# Altium schematic sheet 03-LCD.SchDoc
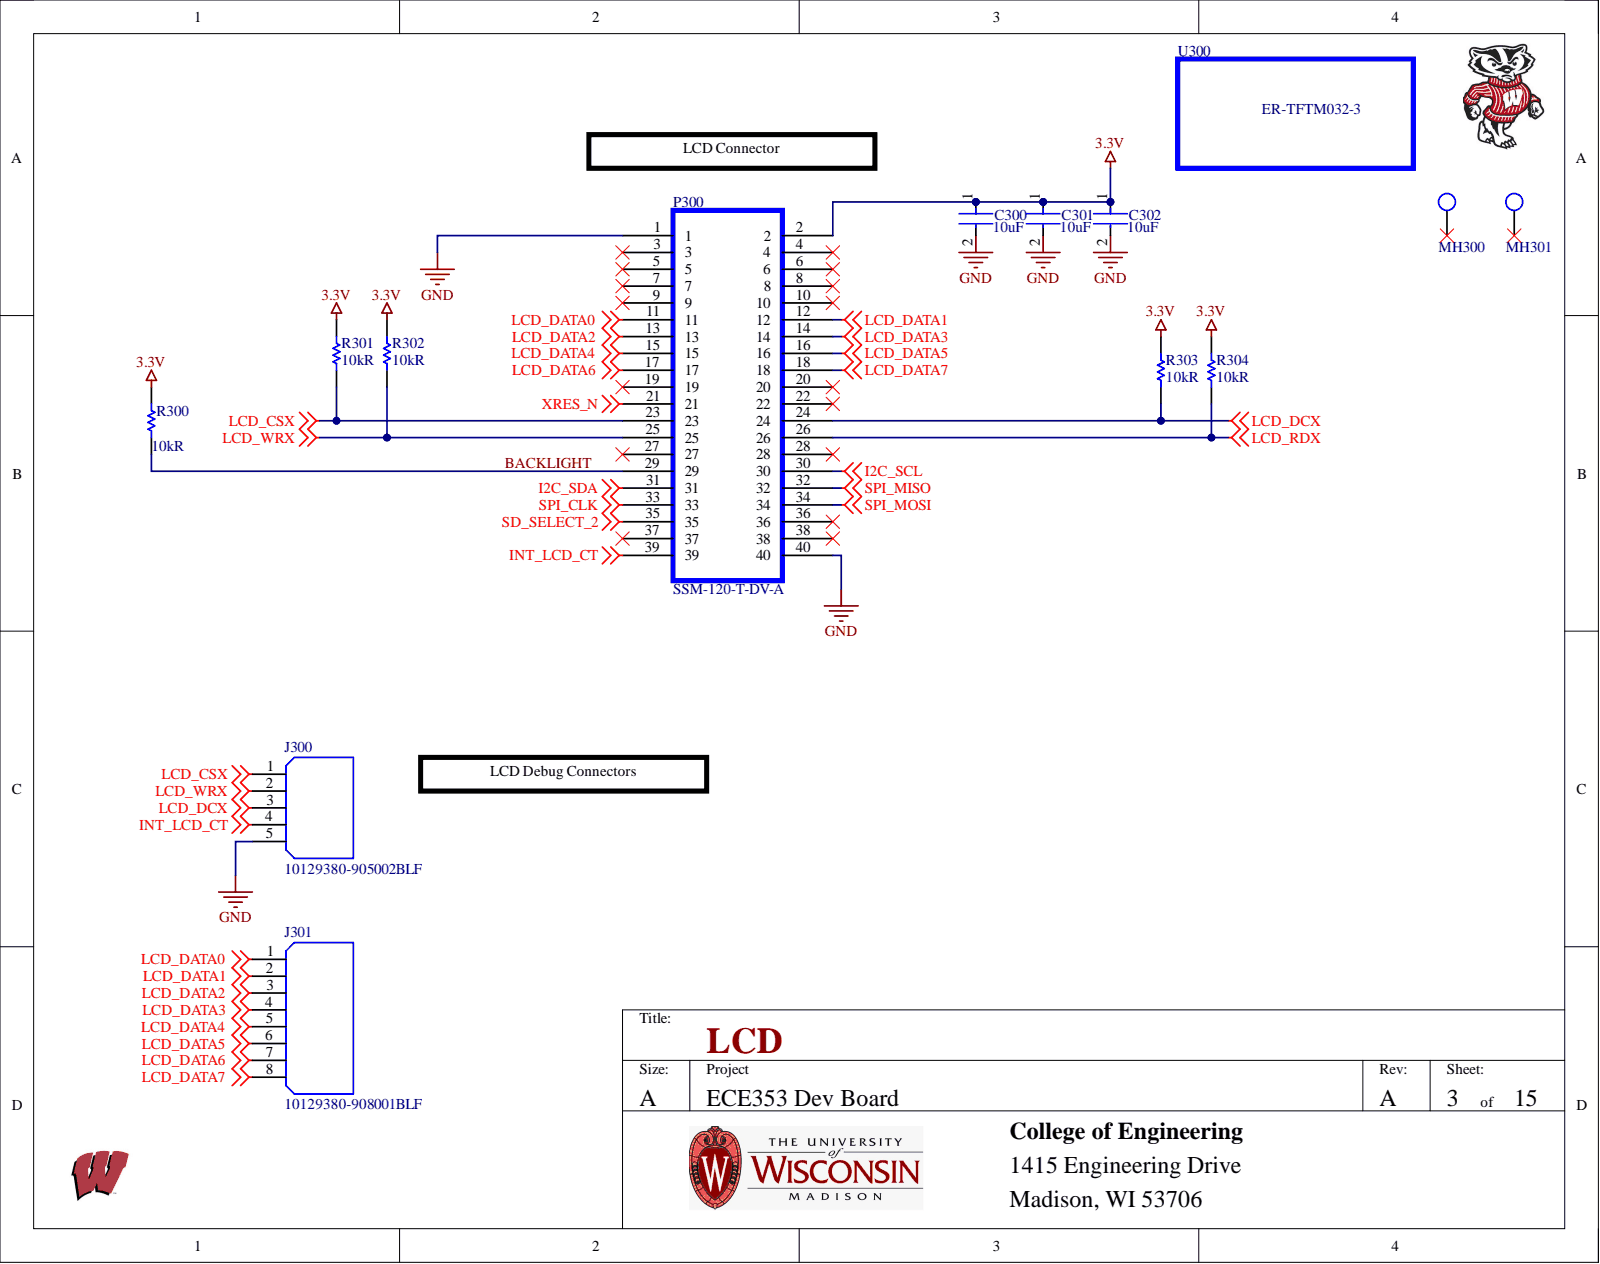
[diagram p0: 03-LCD.SchDoc]

## Parts
C300  value 10uF  Capacitance: 10uF  [FP-C0805C-DG-MFG]  (hidden comment: C0805C106K8PACTU)
C301  value 10uF  Capacitance: 10uF  [FP-C0805C-DG-MFG]  (hidden comment: C0805C106K8PACTU)
C302  value 10uF  Capacitance: 10uF  [FP-C0805C-DG-MFG]  (hidden comment: C0805C106K8PACTU)
J300  10129380-905002BLF  [AMPHENOL_10129380-905002BLF]
J301  10129380-908001BLF  [AMPHENOL_10129380-908001BLF]
MH300  MHOLE_3.5mm  [MTHOLE_145_300KeepOut]  (value not drawn)  (no BOM)
MH301  MHOLE_3.5mm  [MTHOLE_145_300KeepOut]  (value not drawn)  (no BOM)
P300  SSM-120-T-DV-A  [SSM-120-T-DV-A]
R300  Resistance: 10kR  [RESC2013X60X35NL10T20]  (hidden comment: RC0805FR-0710KL)
R301  Resistance: 10kR  [RESC2013X60X35NL10T20]  (hidden comment: RC0805FR-0710KL)
R302  Resistance: 10kR  [RESC2013X60X35NL10T20]  (hidden comment: RC0805FR-0710KL)
R303  Resistance: 10kR  [RESC2013X60X35NL10T20]  (hidden comment: RC0805FR-0710KL)
R304  Resistance: 10kR  [RESC2013X60X35NL10T20]  (hidden comment: RC0805FR-0710KL)
U300  Manufacturer Part Number: ER-TFTM032-3  [ER-TFTM032-3_Mechanical]  (hidden comment: ER-TFTM032-3-Mechanicals)  (no BOM)

## Nets
3.3V: C300.1 C301.1 C302.1 P300.2 R300.2 R301.1 R302.1 R303.1 R304.1
GND: C300.2 C301.2 C302.2 J300.5 P300.1 P300.40
I2C_SCL: P300.30
I2C_SDA: P300.31
INT_LCD_CT: J300.4 P300.39
LCD_CSX: J300.1 P300.23 R301.2
LCD_DATA0: J301.1 P300.11
LCD_DATA1: J301.2 P300.12
LCD_DATA2: J301.3 P300.13
LCD_DATA3: J301.4 P300.14
LCD_DATA4: J301.5 P300.15
LCD_DATA5: J301.6 P300.16
LCD_DATA6: J301.7 P300.17
LCD_DATA7: J301.8 P300.18
LCD_DCX: J300.3 P300.24 R303.2
LCD_RDX: P300.26 R304.2
LCD_WRX: J300.2 P300.25 R302.2
SD_SELECT_2: P300.35
SPI_CLK: P300.33
SPI_MISO: P300.32
SPI_MOSI: P300.34
XRES_N: P300.21
BACKLIGHT: P300.29 R300.1

Not connected: MH300 1; MH301 1; P300 3 4 5 6 7 8 9 10 19 20 22 27 28 36 37 38

## Library data of the parts
C300-C302 = CMP-2007-03243-2: CAP CER 10UF 10V X5R 0805; 3DS Part Number: 220-00001; Manufacturer: Kemet; Dielectric Material: Ceramic; Dissipation Factor: 10%; Temperature Coefficient: 15%; Automotive: No; Case Code (Imperial): 0805; Case Code (Metric): 2012; Case/Package: 0805; Category: Cap; Component Kind: Standard; ComponentLink2Description: Datasheet; ComponentLink2URL: https://content.kemet.com/datasheets/KEM_C1006_X5R_SMD.pdf; Construction: Flat; Contact Plating: Tin,Matte; Depth: 1.25mm; Device Class L1: Passive Components; Device Class L2: Capacitors; Device Class L3: Ceramic Capacitors; Dielectric: X5R; Digikey Description: CAP CER 10UF 10V X5R 0805; Height: 1.4mm; Ipc Land Pattern Name: CAPC200125X125; Lead Free: Yes; Length: 2mm; Library Name: Altium Content Vault; Manufacturer 1: KEMET; Manufacturer Part Number: C0805C106K8PACTU; Manufacturer Part Number 1: C0805C106K8PACTU; Material: Ceramic; Max Operating Temperature: 85°C; Min Operating Temperature: -55°C; Mount: Surface Mount; Mouser Description: CAP CER 10UF 10V X5R 0805; Number of Pins: 2; Octopart: https://octopart.com/c0805c106k8pactu-kemet-98697; Package: C0805C-DG; Package Quantity: 1; Packaging: Tape and Reel; Pricing 1: 1=0.14000, 10=0.10300, 50=0.05840, 100=0.04830, 500=0.03388, 1000=0.02805 (USD); Radiation Hardening: No; Reach SVHC Compliant: Yes; RoHS: TRUE; RoHS Compliant: Yes; Series: C; Stock 1: 8391826; Supplier 1: Digi-Key; Supplier Part Number 1: 399-C0805C106K8PAC7800CT-ND; Temperature: -55°C to +85°C; Temperature Characteristic: X5R; Temperature Range High: +85°C; Temperature Range Low: -55°C; Termination: SMD/SMT; Thickness: 0.055inch; Tolerance: 10%; Voltage: 10V; Voltage Rating: 10V; Voltage Rating (DC): 10V; Width: 0.049inch
J300 = 10129380-905002BLF: Conn Unshrouded Header HDR 5 POS 2.54mm Solder ST SMD Poly Bag; Manufacturer 1: ICC; MF: AMPHENOL ICC / FCI; Availability: In Stock; Check_prices: https://www.snapeda.com/parts/10129380-905002BLF/Amphenol/view-part/?ref=eda; Component Kind: Standard; Library Name: ece353-2022.SchLib; Manufacturer Part Number 1: 10129380-905002BLF; MP: 10129380-905002BLF; Package: None; Price: None; Purchase-URL: https://pricing.snapeda.com/search/part/10129380-905002BLF/?ref=eda; RoHS: No; SnapEDA_Link: https://www.snapeda.com/parts/10129380-905002BLF/Amphenol/view-part/?ref=snap; Supplier 1: Digi-Key; Supplier Part Number 1: 10129380-905002BLF-ND
J301 = 10129380-908001BLF: Conn Unshrouded Header HDR 8 POS 2.54mm Solder ST SMD Poly Bag; Manufacturer 1: ICC; MF: Amphenol ICC (FCI); Availability: In Stock; Check_prices: https://www.snapeda.com/parts/10129380-908001BLF/Amphenol+FCI/view-part/?ref=eda; Component Kind: Standard; Library Name: ece353-2022.SchLib; Manufacturer Part Number 1: 10129380-908001BLF; MP: 10129380-908001BLF; Package: None; Price: None; Purchase-URL: https://pricing.snapeda.com/search/part/10129380-908001BLF/?ref=eda; RoHS: No; SnapEDA_Link: https://www.snapeda.com/parts/10129380-908001BLF/Amphenol+FCI/view-part/?ref=snap; Supplier 1: Digi-Key; Supplier Part Number 1: 10129380-908001BLF-ND
MH300 MH301 = MHOLE_3.5mm: Mounting Holde; Manufacturer Part Number: UW MTHOLE; Library Name: ece353-2016.SchLib
P300 = SSM-120-T-DV-A: Headers & Wire Housings Surface Mount PCB Socket Strips, 0.100 pitch; Manufacturer: Samtec; Connector Type: Receptacle; Gender: Female; Number of Contacts: 40; Pitch: 2.54mm; Voltage Rating (AC): 405V; Voltage Rating (DC): 572V; Category: Connectors; Component Kind: Standard; ComponentLink1Description: https://datasheet.ciiva.com/745/npxcxx2kfmx-rc10488-d-745417.pdf?src-supplier=Digi-Key; ComponentLink1URL: https://datasheet.ciiva.com/745/npxcxx2kfmx-rc10488-d-745417.pdf?src-supplier=Digi-Key; ComponentLink2Description: http://cloud.samtec.com/catalog_english/SSM_SM.PDF?src-supplier=Avnet; ComponentLink2URL: http://cloud.samtec.com/catalog_english/SSM_SM.PDF?src-supplier=Avnet; Contact Finish - Mating: TIN; Contact Material: Bronze; Contact Plating: Tin; Contact Shape: Square; Contact Type: Female Socket; Fastening Type: Pull; Insulation Color: Black; Insulation Material: Polymer; Library Name: ece353-2016.SchLib; Manufacturer Part Number: SSM-120-T-DV-A; Max Operating Temperature: 125°C; Min Operating Temperature: -55°C; Mounting Technology: Surface Mount; Number of Positions: 40; Number of Rows: 2; Pricing: 1=6.6 (USD); RoHS: Yes; RoHS Compliant: Yes; Series: SSM; Supplier: Digi-Key; Supplier Part Number: SSM-120-T-DV-A-ND; Termination: Solder
R300-R304 = CMP-1659-00013-4: Chip Resistor, 10 KOhm, +/- 1%, 0.125 W, -55 to 155 degC, 0805 (2012 Metric), RoHS, Tape and Reel; Manufacturer: Yageo; Max Power Dissipation: 125mW; Temperature Coefficient: 100ppm/°C; Voltage Rating: 150 V; Case Code (Imperial): 0805; Case Code (Metric): 2012; Case/Package: 0805; Category: Chip SMD Resistors; Component Kind: Standard; ComponentLink1Description: Manufacturer URL; ComponentLink1URL: http://www.yageo.com/; ComponentLink2Description: Datasheet; ComponentLink2URL: http://www.yageo.com/documents/recent/PYu-RC_Group_51_RoHS_L_04.pdf; Construction: Rectangular; DatasheetVersion: Rev. 4, 02/2016; Depth: 1.25mm; Features: Moisture Resistant; Height: 0.5 mm; Lead Free: Lead Free; Length: 2 mm; Library Name: Altium Content Vault; Manufacturer 1: Yageo; Manufacturer Part Number 1: RC0805FR-0710KL; Max Operating Temperature: 155 degC; Military Standard: Not; Min Operating Temperature: -55 degC; Mount: Surface Mount; Mounting Technology: Surface Mount; Number of Terminations: 2; Package Quantity: 5000; PackageDescription: 2-Pin Surface Mounted Device, Body 2 x 1.25 mm; PackageReference: 0805; Packaging: Tape and Reel; Pins: 2; Power Rating: 125mW; Pricing 1: 1=0.10000, 10=0.03700, 100=0.01520, 1000=0.00682, 2500=0.00592 (USD); REACH SVHC: No SVHC; Resistance Tolerance: 1%; Resistor Type: Thick Film; RoHS: Yes; RoHS Compliant: Yes; RoHSCompliant: true; Series: RC; Size Code: 0805; Stock 1: 12202331; Supplier 1: Digi-Key; Supplier Part Number 1: 311-10.0KCRCT-ND; Technology: THICK FILM; Termination: SMD/SMT; Tolerance: 1%; Width: 0.049inch
U300 = ER-TFTM032-3-Mechanicals: 3.2" LCD Screen 320x240; Library Name: ece353-2016.SchLib
